# Altium schematic sheet sensor-1.SchDoc(sensor-1)
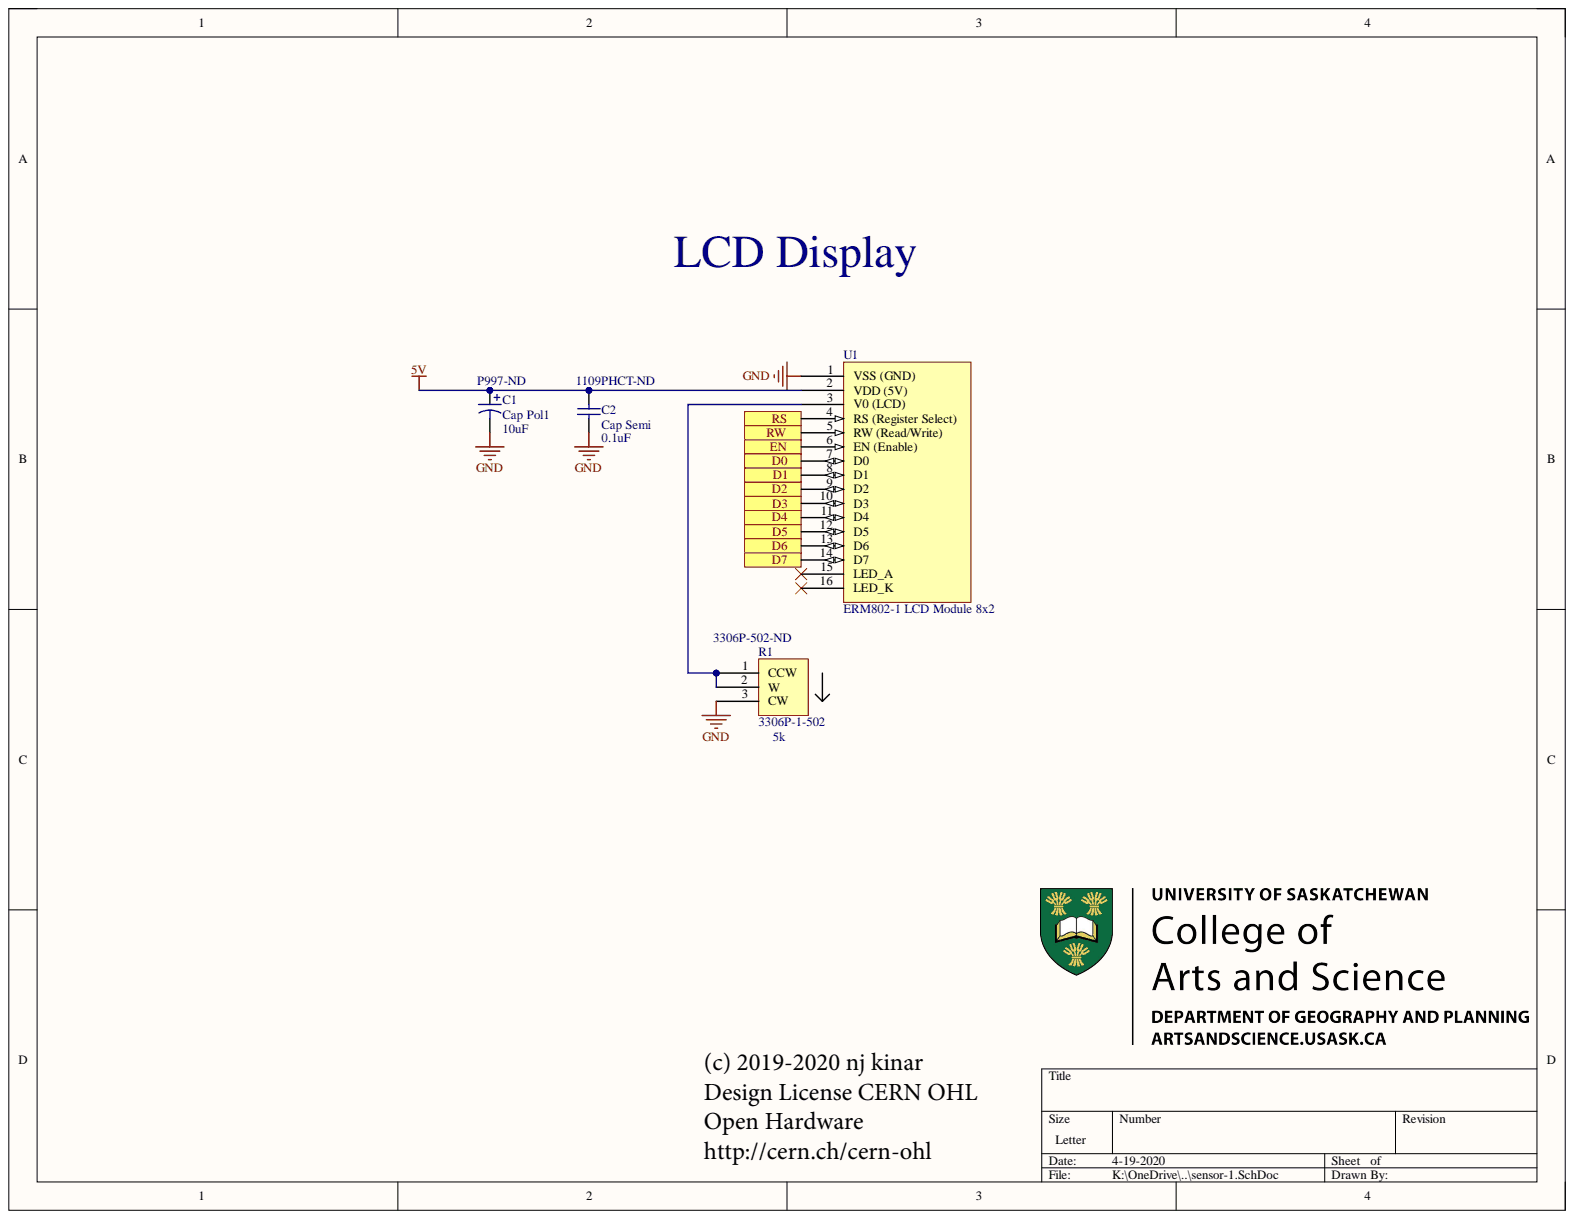
[diagram p0: sensor-1.SchDoc]

## Parts
C1  Cap Pol1  value 10uF  Capacitance: 10uF  Supplier Part Number 1: P997-ND  [ECE-A1HKS100]
C2  Cap Semi  value 0.1uF  Supplier Part Number 1: 1109PHCT-ND  [A104K15X7RF5TAA]
R1  3306P-1-502  Supplier Part Number 1: 3306P-502-ND  [3306P-1-502]
U1  ERM802-1 LCD Module 8x2  [ERM802-1]

## Ports
D0, D1, D2, D3, D4, D5, D6, D7, EN, RS, RW

## Nets
5V: C1.1 C2.2 U1.2
GND: C1.2 C2.1 R1.3 U1.1
D0: U1.7 ; port D0
D1: U1.8 ; port D1
D2: U1.9 ; port D2
D3: U1.10 ; port D3
D4: U1.11 ; port D4
D5: U1.12 ; port D5
D6: U1.13 ; port D6
D7: U1.14 ; port D7
EN: U1.6 ; port EN
RS: U1.4 ; port RS
RW: U1.5 ; port RW
NetR1_1: R1.1 R1.2 U1.3

Not connected: U1 15 16

## Library data of the parts
C1 = Cap Pol1: Polarized Capacitor (Radial); Manufacturer: Panasonic; Lead Diameter: 0.45mm; Leakage Current: 3A; Life (Hours): 1000Hour; Polarity: Polar; Ripple Current: 35mA; Tolerance: 20%; Voltage: 50V; Case/Package: Radial; Component Kind: Standard; ComponentLink1Description: https://datasheet.ciiva.com/11778/0900766b800274c4-11778985.pdf?src-supplier=RSComponents; ComponentLink1URL: https://datasheet.ciiva.com/11778/0900766b800274c4-11778985.pdf?src-supplier=RSComponents; ComponentLink2Description: https://datasheet.ciiva.com/17536/aba0000ce15-17536597.pdf?src-supplier=Avnet+Europe; ComponentLink2URL: https://datasheet.ciiva.com/17536/aba0000ce15-17536597.pdf?src-supplier=Avnet+Europe; ComponentLink3Description: https://datasheet.ciiva.com/14594/70067878-14594328.pdf?src-supplier=Allied+Electronics; ComponentLink3URL: https://datasheet.ciiva.com/14594/70067878-14594328.pdf?src-supplier=Allied+Electronics; ComponentLink4Description: https://datasheet.ciiva.com/16205/aba0000ce15-16205737.pdf?src-supplier=Future+Electronics; ComponentLink4URL: https://datasheet.ciiva.com/16205/aba0000ce15-16205737.pdf?src-supplier=Future+Electronics; ComponentLink5Description: https://datasheet.ciiva.com/24025/a-e-461514-24025641.pdf?src-supplier=Mouser; ComponentLink5URL: https://datasheet.ciiva.com/24025/a-e-461514-24025641.pdf?src-supplier=Mouser; ComponentLink6Description: https://datasheet.ciiva.com/43459/1339471-43459545.pdf?src-supplier=Farnell; ComponentLink6URL: https://datasheet.ciiva.com/43459/1339471-43459545.pdf?src-supplier=Farnell; ComponentLink7Description: https://datasheet.ciiva.com/15166/getdatasheetpartid-169217-15166123.pdf?src-supplier=Verical; ComponentLink7URL: https://datasheet.ciiva.com/15166/getdatasheetpartid-169217-15166123.pdf?src-supplier=Verical; ComponentLink8Description: https://datasheet.ciiva.com/46397/1339471-46397117.pdf?src-supplier=Element14; ComponentLink8URL: https://datasheet.ciiva.com/46397/1339471-46397117.pdf?src-supplier=Element14; ComponentLink9Description: https://datasheet.ciiva.com/2983/aba0000ce15-2983886.pdf?src-supplier=Avnet; ComponentLink9URL: https://datasheet.ciiva.com/2983/aba0000ce15-2983886.pdf?src-supplier=Avnet; Diameter: 6.3mm; Height: 5mm; Height - Seated (Max): 0.197inch; LatestRevisionDate: 17-Jul-2002; LatestRevisionNote: Re-released for DXP Platform.; Lead Free: Lead Free; Lead Pitch: 2.5mm; Length: 5mm; Library Name: Miscellaneous Devices.IntLib; Manufacturer Part Number: ECE-A1HKS100; Max Operating Temperature: 85°C; Min Operating Temperature: -40°C; Mount: Through Hole,PCB; Number of Pins: 2; Package Quantity: 200; PackageDescription: Polarized Capacitor (Radial); 2 Leads; PackageReference: RB7.6-15; Packaging: Bulk; Pricing 1: 1=0.10000, 10=0.03000 (USD); Published: 8-Jun-2000; Radiation Hardening: No; REACH SVHC: No SVHC; Ripple Current (AC): 35mA; RoHS: Yes; Signal Integrity: Cap Pol; Simulation: CAP; Stock 1: 132836; Supplier 1: Digi-Key; Termination: Radial; Voltage Rating: 50V; Voltage Rating (DC): 50V; Weight: 0.01lb; Width: 0.5inch; Publisher: Altium Limited
C2 = Cap Semi: Capacitor (Semiconductor SIM Model); Manufacturer: Vishay BCcomponents; Capacitance: 100nF; Dielectric: X7R; Lead Diameter: 0.5mm; Lead Length: 24.3mm; Tolerance: 10%; Voltage: 50V; Case/Package: Dipped; Component Kind: Standard; ComponentLink1Description: https://datasheet.ciiva.com/145/aseries-145936.pdf?src-supplier=Digi-Key; ComponentLink1URL: https://datasheet.ciiva.com/145/aseries-145936.pdf?src-supplier=Digi-Key; ComponentLink2Description: https://datasheet.ciiva.com/5815/1734588-5815375.pdf?src-supplier=Farnell; ComponentLink2URL: https://datasheet.ciiva.com/5815/1734588-5815375.pdf?src-supplier=Farnell; ComponentLink3Description: https://datasheet.ciiva.com/18442/70122957-18442089.pdf?src-supplier=Allied+Electronics; ComponentLink3URL: https://datasheet.ciiva.com/18442/70122957-18442089.pdf?src-supplier=Allied+Electronics; ComponentLink4Description: https://datasheet.ciiva.com/2988/aseries-2988250.pdf?src-supplier=Avnet; ComponentLink4URL: https://datasheet.ciiva.com/2988/aseries-2988250.pdf?src-supplier=Avnet; ComponentLink5Description: https://datasheet.ciiva.com/17444/aseries-17444908.pdf?src-supplier=Avnet+Europe; ComponentLink5URL: https://datasheet.ciiva.com/17444/aseries-17444908.pdf?src-supplier=Avnet+Europe; ComponentLink6Description: https://datasheet.ciiva.com/46418/1796772-46418252.pdf?src-supplier=Element14; ComponentLink6URL: https://datasheet.ciiva.com/46418/1796772-46418252.pdf?src-supplier=Element14; ComponentLink7Description: https://datasheet.ciiva.com/145/aseries-145935.pdf?src-supplier=Digi-Key; ComponentLink7URL: https://datasheet.ciiva.com/145/aseries-145935.pdf?src-supplier=Digi-Key; Contact Plating: Tin; Diameter: 2.5mm; LatestRevisionDate: 29-May-2009; LatestRevisionNote: IPC-7351 Footprint Added.; Lead Free: Lead Free; Length: 3.8mm; Library Name: Miscellaneous Devices.IntLib; Manufacturer Part Number: A104K15X7RF5TAA; Max Operating Temperature: 125°C; Min Operating Temperature: -55°C; Mount: Through Hole,PCB,Axial; Package Quantity: 1; PackageDescription: Chip Capacitor; PackageReference: C1206; Packaging: Tape and Reel; Pricing 1: 1=0.24000, 10=0.16500, 100=0.09350, 500=0.06598, 1000=0.05773, 2500=0.05498 (USD); Published: 8-Jun-2000; Radiation Hardening: No; REACH SVHC: Unknown; RoHS: Yes; Signal Integrity: Cap Semi; Simulation: CAP; Stock 1: 502711; Supplier 1: Digi-Key; Termination: Axial; Voltage Rating: 50V; Voltage Rating (DC): 50V; Publisher: Altium Limited
R1 = 3306P-1-502: TRIMMER 5K OHM 0.2W PC PIN TOP; Manufacturer: Bourns; Max Power Dissipation: 200mW; Number of Turns: 1; Pitch: 2.5mm; Resistance: 5kR; Temperature Coefficient: 250ppm/°C; Tolerance: 25%; Voltage Rating: 100V; Color: Blue; Component Kind: Standard; ComponentLink1Description: https://datasheet.ciiva.com/19466/769961-19466616.pdf?src-supplier=Jameco; ComponentLink1URL: https://datasheet.ciiva.com/19466/769961-19466616.pdf?src-supplier=Jameco; ComponentLink2Description: https://datasheet.ciiva.com/11830/0900766b80dbb841-11830858.pdf?src-supplier=RSComponents; ComponentLink2URL: https://datasheet.ciiva.com/11830/0900766b80dbb841-11830858.pdf?src-supplier=RSComponents; ComponentLink3Description: https://datasheet.ciiva.com/29122/447317-da-01-en-trimmer-tht3306p-1-502-5k-0-2w-29122254.pdf?src-supplier=Conrad; ComponentLink3URL: https://datasheet.ciiva.com/29122/447317-da-01-en-trimmer-tht3306p-1-502-5k-0-2w-29122254.pdf?src-supplier=Conrad; ComponentLink4Description: https://datasheet.ciiva.com/2160/3306-2160165.pdf?src-supplier=Digi-Key; ComponentLink4URL: https://datasheet.ciiva.com/2160/3306-2160165.pdf?src-supplier=Digi-Key; ComponentLink5Description: https://datasheet.ciiva.com/20163/67-0600-20163929.pdf?src-supplier=Rapid+Electronics; ComponentLink5URL: https://datasheet.ciiva.com/20163/67-0600-20163929.pdf?src-supplier=Rapid+Electronics; ComponentLink6Description: https://datasheet.ciiva.com/16715/70154382-16715498.pdf?src-supplier=Allied+Electronics; ComponentLink6URL: https://datasheet.ciiva.com/16715/70154382-16715498.pdf?src-supplier=Allied+Electronics; ComponentLink7Description: https://datasheet.ciiva.com/15157/getdatasheetpartid-379572-15157051.pdf?src-supplier=Verical; ComponentLink7URL: https://datasheet.ciiva.com/15157/getdatasheetpartid-379572-15157051.pdf?src-supplier=Verical; ComponentLink8Description: https://datasheet.ciiva.com/1477/1815113-1477453.pdf?src-supplier=Element14; ComponentLink8URL: https://datasheet.ciiva.com/1477/1815113-1477453.pdf?src-supplier=Element14; Composition: Cermet; Contact Plating: Tin; Depth: 8.1mm; Diameter: 6.81mm; Height: 7.62mm; Lead Free: Lead Free; Length: 6.81mm; Library Name: bourns.IntLib; Manufacturer Part Number: 3306P-1-502; Max Operating Temperature: 100°C; Military Standard: Not; Min Operating Temperature: -25°C; Mount: Through Hole,PCB; Package Quantity: 200; Packaging: Bulk; Power Rating: 200mW; Pricing 1: 1=0.43000, 10=0.40000, 25=0.36000, 50=0.34800, 100=0.33600, 250=0.31200, 500=0.28800, 1000=0.27000, 5000=0.20400 (USD); Radiation Hardening: No; REACH SVHC: No SVHC; RoHS: Yes; Stock 1: 2392; Supplier 1: Digi-Key; Taper: Linear; Termination: Pin; Weight: 360mg; Width: 6.81mm
U1 = ERM802-1: Component Kind: Standard; Description: ERM802-1 LCD Module 8x2; Library Name: EastRising.IntLib
